# Altium schematic sheet [07] - USB-C Connector.SchDoc("[07] - USB-C Connector")
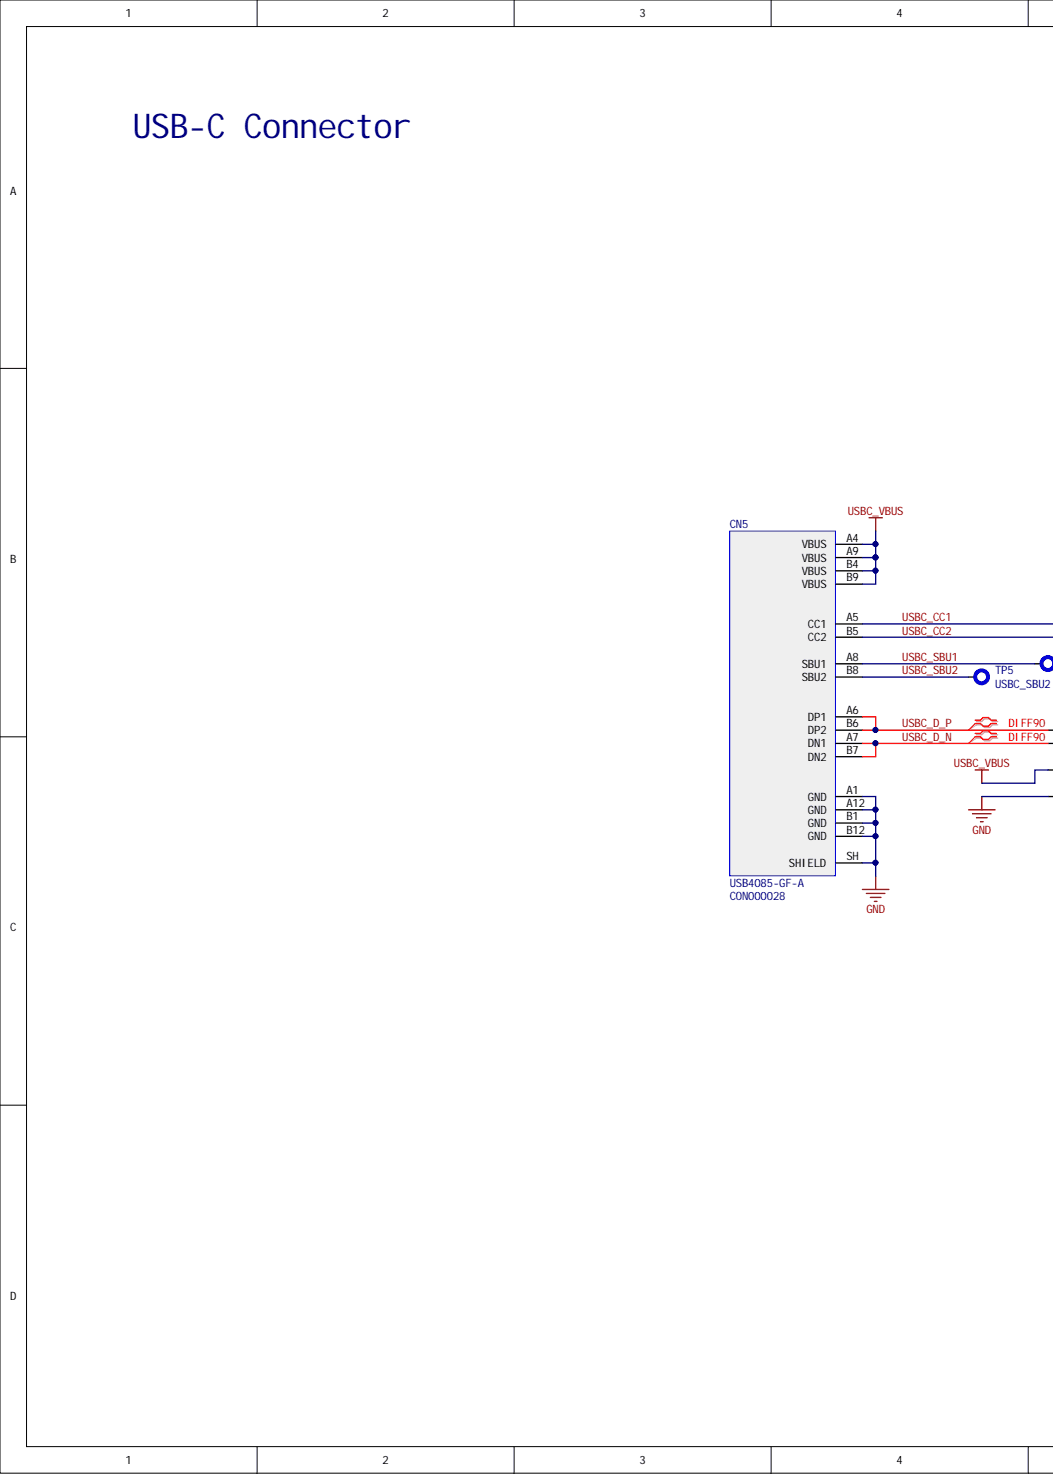
[diagram p0: [07] - USB-C Connector.SchDoc, left]
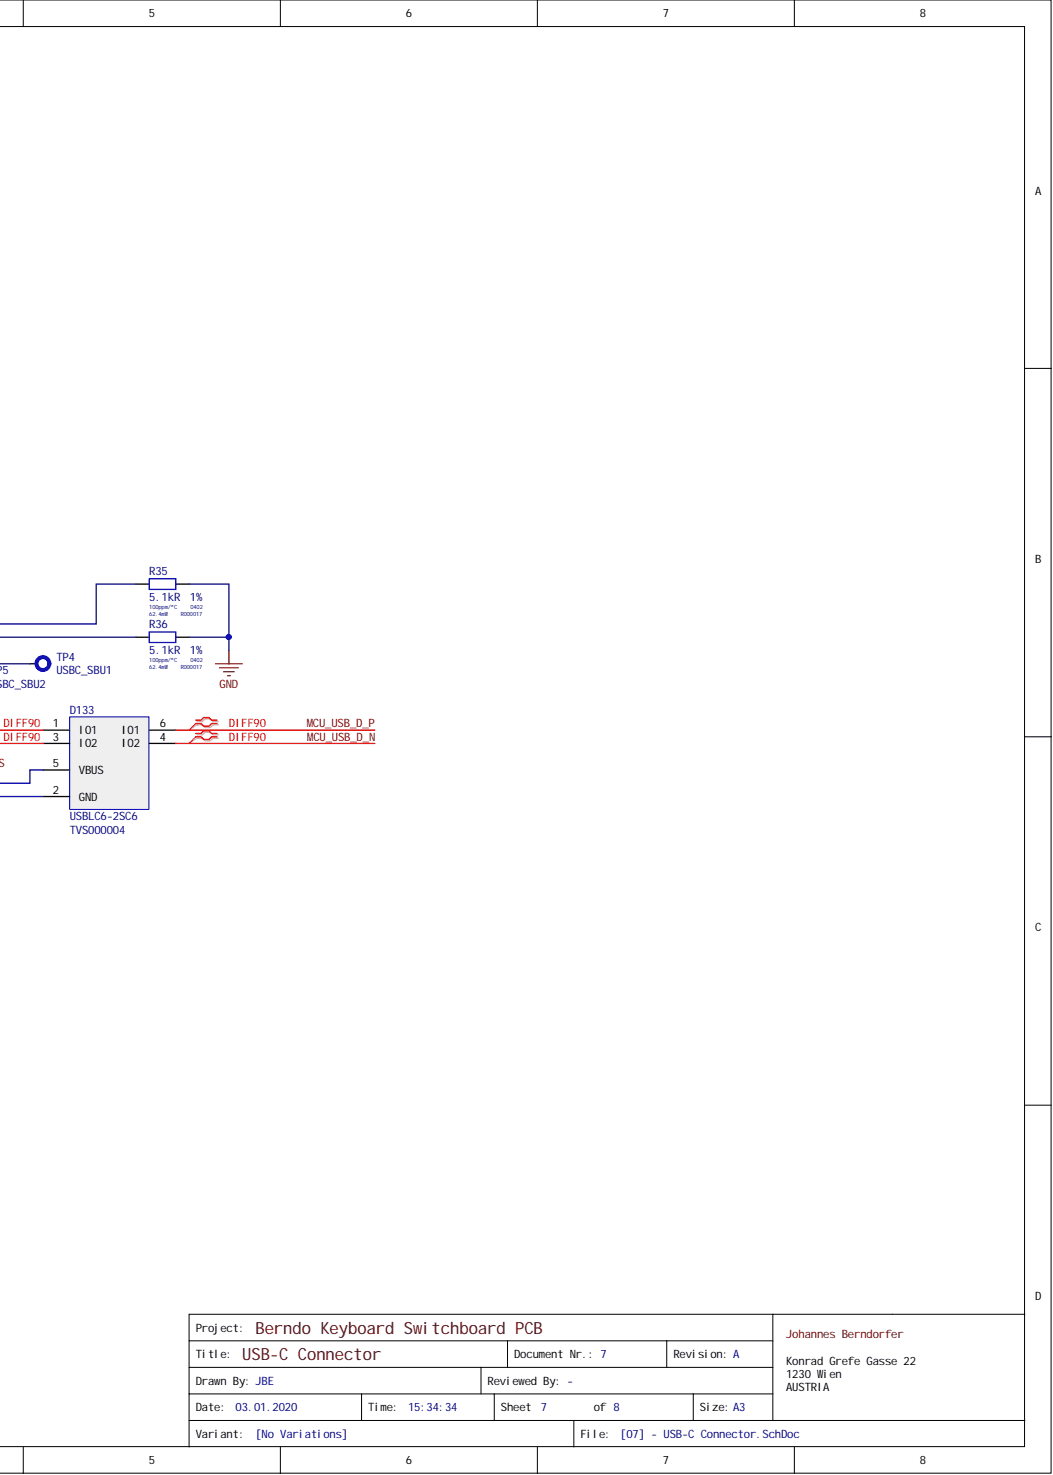
[diagram p1: [07] - USB-C Connector.SchDoc, right]

## Parts
CN5  USB4085-GF-A  [CON-THT-USB-C-16POS-USB4085-GCT]
D133  USBLC6-2SC6  Internal Part Number: TVS000004  [SOT-23-6L-H1.45MM-ST]
R35  Tolerance: 1%  Resistance: 5.1kR  Case Code (Imperial): 0402  [RES-SMD-0402-0.4MM-IPC-MED]  (hidden comment: RC0402FR-075K1L)
R36  Tolerance: 1%  Temperature Coefficient: 100ppm/°C  Resistance: 5.1kR  Max Power Dissipation: 62.4mW  Internal Part Number: R000017  Case Code (Imperial): 0402  [RES-SMD-0402-0.4MM-IPC-MED]  (hidden comment: RC0402FR-075K1L)
TP4  USBC_SBU1  [TP-SMD-CIRCULAR-1MM]
TP5  USBC_SBU2  [TP-SMD-CIRCULAR-1MM]

## Nets
GND: CN5.A1(GND) CN5.A12(GND) CN5.B1(GND) CN5.B12(GND) CN5.SH(SHIELD) D133.2(GND) R35.2 R36.2
USBC_VBUS: CN5.A4(VBUS) CN5.A9(VBUS) CN5.B4(VBUS) CN5.B9(VBUS) D133.5(VBUS)
MCU_USB_D_N: D133.4(IO2)
MCU_USB_D_P: D133.6(IO1)
USBC_CC1: CN5.A5(CC1) R35.1
USBC_CC2: CN5.B5(CC2) R36.1
USBC_D_N: CN5.A7(DN1) CN5.B7(DN2) D133.3(IO2)
USBC_D_P: CN5.A6(DP1) CN5.B6(DP2) D133.1(IO1)
USBC_SBU1: CN5.A8(SBU1) TP4.1
USBC_SBU2: CN5.B8(SBU2) TP5.1

## Library data of the parts
CN5 = USB4085-GF-A: CON USB TYPE C THROUGH HOLE 16POS; Manufacturer: Global Connector Technology; Internal Part Number: CON000028; USB Standard: USB 2.0; Number of Positions: 16; Gender: Receptacle; Supplier Part Number 1: 2073-USB4085-GF-ACT-ND; Supplier 1: Digi-Key; Stock 1: 0; RoHS: No; Orientation: Right Angle; Manufacturer Part Number: USB4085-GF-A; Library Name: Altium_Lib_Standard.IntLib; Contact Plating: Gold; Contact Material: Copper Alloy; ComponentLink2URL: https://datasheet.ciiva.com/71788/usb4085-71788147.pdf?src-supplier=Digi-Key; ComponentLink2Description: https://datasheet.ciiva.com/71788/usb4085-71788147.pdf?src-supplier=Digi-Key; ComponentLink1URL: https://datasheet.ciiva.com/71788/2674755-71788146.pdf?src-supplier=Element14; ComponentLink1Description: https://datasheet.ciiva.com/71788/2674755-71788146.pdf?src-supplier=Element14; Component Kind: Standard
D133 = USBLC6-2SC6: TVS DIODE 2CH 5.25V 17V USB2.0 SOT23-6L; Manufacturer: STMicroelectronics; Working Voltage: 5V; Test Current: 1mA; Reverse Breakdown Voltage: 6V; Power Line Protection: Yes; Polarity: Unidirectional,Bidirectional; Peak Pulse Current: 5A; Operating Supply Voltage: 1.1V; Number of Elements: 1; Number of Channels: 2; Max Reverse Leakage Current: 150nA; Element Configuration: Dual; Data Rate: 480Mbps; Capacitance: 3.5pF; Width: 1.75mm; Termination: SMD/SMT; Supplier Part Number 1: 497-5235-1-ND; Supplier 1: Digi-Key; Stock 1: 30680; RoHS: Yes; Reverse Standoff Voltage: 5.25V; REACH SVHC: No SVHC; Radiation Hardening: No; Pricing 1: 1 = 0,47000, 10 = 0,39900, 100 = 0,29820, 500 = 0,23430, 1000 = 0,18105 (USD); Packaging: Tape and Reel; Package Quantity: 3000; Number of Pins: 6; Number of Bidirectional Channels: 2; Mount: Surface Mount; Min Operating Temperature: -40°C; Min Breakdown Voltage: 6V; Max Operating Temperature: 125°C; Max Breakdown Voltage: 6V; Manufacturer Part Number: USBLC6-2SC6; Library Name: Altium_Lib_Standard.IntLib; Length: 3.05mm; Leakage Current: 150nA; Lead Free: Lead Free; Height: 1.3mm; ESD Protection: Yes; Direction: Unidirectional,Bidirectional; Depth: 1.75mm; Contact Plating: Tin,Matte; ComponentLink4URL: https://datasheet.ciiva.com/16200/cd00050750-16200026.pdf?src-supplier=Future+Electronics; ComponentLink4Description: https://datasheet.ciiva.com/16200/cd00050750-16200026.pdf?src-supplier=Future+Electronics; ComponentLink3URL: https://datasheet.ciiva.com/26787/usblc6-2sc6-26787908.pdf?src-supplier=IIIC; ComponentLink3Description: https://datasheet.ciiva.com/26787/usblc6-2sc6-26787908.pdf?src-supplier=IIIC; ComponentLink2URL: https://datasheet.ciiva.com/4508/cd00050750-113117-4508433.pdf?src-supplier=Mouser; ComponentLink2Description: https://datasheet.ciiva.com/4508/cd00050750-113117-4508433.pdf?src-supplier=Mouser; ComponentLink1URL: https://datasheet.ciiva.com/1268/1690354-1268384.pdf?src-supplier=Element14; ComponentLink1Description: https://datasheet.ciiva.com/1268/1690354-1268384.pdf?src-supplier=Element14; Component Kind: Standard; Clamping Voltage: 17V; Case/Package: SOT-23; Breakdown Voltage: 6V
R35 = RC0402FR-075K1L: RES 5K1 1% 1/16W SMD 0402 YAEGO; Manufacturer: Yageo; Internal Part Number: R000017; Working Voltage: 50V; Temperature Coefficient: 100ppm/°C; Power Rating: 63mW; Max Power Dissipation: 62.4mW; Width: 0.02inch; Voltage Rating (DC): 50V; Voltage Rating: 50V; Termination: SMD/SMT; Supplier Part Number 1: 311-5.10KLRCT-ND; Supplier 1: Digi-Key; Stock 1: 3098997; RoHS: Yes; REACH SVHC: Unknown; Pricing 1: 1 = 0,10000, 10 = 0,01600, 100 = 0,00640, 1000 = 0,00289, 2500 = 0,00251, 5000 = 0,00207 (USD); Packaging: Tape and Reel; Package Quantity: 1; Number of Terminations: 2; Min Operating Temperature: -55°C; Military Standard: Not; Max Operating Temperature: 155°C; Manufacturer Part Number: RC0402FR-075K1L; Library Name: Altium_Lib_Standard.IntLib; Length: 1mm; Lead Free: Lead Free; Height: 0.016inch; Features: Moisture Resistant; Depth: 0.5mm; Composition: Thick Film; ComponentLink8URL: https://datasheet.ciiva.com/7983/1018594-7983519.pdf?src-supplier=Element14; ComponentLink8Description: https://datasheet.ciiva.com/7983/1018594-7983519.pdf?src-supplier=Element14; ComponentLink7URL: https://datasheet.ciiva.com/12558/pyu-rc0402-51-rohs-l-6-r-8721-12558460.pdf?src-supplier=Mouser; ComponentLink7Description: https://datasheet.ciiva.com/12558/pyu-rc0402-51-rohs-l-6-r-8721-12558460.pdf?src-supplier=Mouser; ComponentLink6URL: https://datasheet.ciiva.com/16744/pyu-rc0402-51-rohs-l-6-r-16744283.pdf?src-supplier=Future+Electronics; ComponentLink6Description: https://datasheet.ciiva.com/16744/pyu-rc0402-51-rohs-l-6-r-16744283.pdf?src-supplier=Future+Electronics; ComponentLink5URL: https://datasheet.ciiva.com/2453/pyu-r-int-thick-7-2453402.pdf?src-supplier=Digi-Key; ComponentLink5Description: https://datasheet.ciiva.com/2453/pyu-r-int-thick-7-2453402.pdf?src-supplier=Digi-Key; ComponentLink4URL: https://datasheet.ciiva.com/2453/pyu-r-int-thick-7-2453401.pdf?src-supplier=Digi-Key; ComponentLink4Description: https://datasheet.ciiva.com/2453/pyu-r-int-thick-7-2453401.pdf?src-supplier=Digi-Key; ComponentLink3URL: https://datasheet.ciiva.com/46394/1018594-46394936.pdf?src-supplier=Element14; ComponentLink3Description: https://datasheet.ciiva.com/46394/1018594-46394936.pdf?src-supplier=Element14; ComponentLink2URL: https://datasheet.ciiva.com/2453/pyu-r-int-thick-7-2453400.pdf?src-supplier=Digi-Key; ComponentLink2Description: https://datasheet.ciiva.com/2453/pyu-r-int-thick-7-2453400.pdf?src-supplier=Digi-Key; ComponentLink1URL: https://datasheet.ciiva.com/15189/getdatasheetpartid-323636-15189493.pdf?src-supplier=Verical; ComponentLink1Description: https://datasheet.ciiva.com/15189/getdatasheetpartid-323636-15189493.pdf?src-supplier=Verical; Component Kind: Standard; Case Code (Metric): 1005; Case/Package: 0402
R36 = RC0402FR-075K1L: RES 5K1 1% 1/16W SMD 0402 YAEGO; Manufacturer: Yageo; Working Voltage: 50V; Power Rating: 63mW; Width: 0.02inch; Voltage Rating (DC): 50V; Voltage Rating: 50V; Termination: SMD/SMT; Supplier Part Number 1: 311-5.10KLRCT-ND; Supplier 1: Digi-Key; Stock 1: 3098997; RoHS: Yes; REACH SVHC: Unknown; Pricing 1: 1 = 0,10000, 10 = 0,01600, 100 = 0,00640, 1000 = 0,00289, 2500 = 0,00251, 5000 = 0,00207 (USD); Packaging: Tape and Reel; Package Quantity: 1; Number of Terminations: 2; Min Operating Temperature: -55°C; Military Standard: Not; Max Operating Temperature: 155°C; Manufacturer Part Number: RC0402FR-075K1L; Library Name: Altium_Lib_Standard.IntLib; Length: 1mm; Lead Free: Lead Free; Height: 0.016inch; Features: Moisture Resistant; Depth: 0.5mm; Composition: Thick Film; ComponentLink8URL: https://datasheet.ciiva.com/7983/1018594-7983519.pdf?src-supplier=Element14; ComponentLink8Description: https://datasheet.ciiva.com/7983/1018594-7983519.pdf?src-supplier=Element14; ComponentLink7URL: https://datasheet.ciiva.com/12558/pyu-rc0402-51-rohs-l-6-r-8721-12558460.pdf?src-supplier=Mouser; ComponentLink7Description: https://datasheet.ciiva.com/12558/pyu-rc0402-51-rohs-l-6-r-8721-12558460.pdf?src-supplier=Mouser; ComponentLink6URL: https://datasheet.ciiva.com/16744/pyu-rc0402-51-rohs-l-6-r-16744283.pdf?src-supplier=Future+Electronics; ComponentLink6Description: https://datasheet.ciiva.com/16744/pyu-rc0402-51-rohs-l-6-r-16744283.pdf?src-supplier=Future+Electronics; ComponentLink5URL: https://datasheet.ciiva.com/2453/pyu-r-int-thick-7-2453402.pdf?src-supplier=Digi-Key; ComponentLink5Description: https://datasheet.ciiva.com/2453/pyu-r-int-thick-7-2453402.pdf?src-supplier=Digi-Key; ComponentLink4URL: https://datasheet.ciiva.com/2453/pyu-r-int-thick-7-2453401.pdf?src-supplier=Digi-Key; ComponentLink4Description: https://datasheet.ciiva.com/2453/pyu-r-int-thick-7-2453401.pdf?src-supplier=Digi-Key; ComponentLink3URL: https://datasheet.ciiva.com/46394/1018594-46394936.pdf?src-supplier=Element14; ComponentLink3Description: https://datasheet.ciiva.com/46394/1018594-46394936.pdf?src-supplier=Element14; ComponentLink2URL: https://datasheet.ciiva.com/2453/pyu-r-int-thick-7-2453400.pdf?src-supplier=Digi-Key; ComponentLink2Description: https://datasheet.ciiva.com/2453/pyu-r-int-thick-7-2453400.pdf?src-supplier=Digi-Key; ComponentLink1URL: https://datasheet.ciiva.com/15189/getdatasheetpartid-323636-15189493.pdf?src-supplier=Verical; ComponentLink1Description: https://datasheet.ciiva.com/15189/getdatasheetpartid-323636-15189493.pdf?src-supplier=Verical; Component Kind: Standard; Case Code (Metric): 1005; Case/Package: 0402
TP4 TP5 = TESTPOINT_CIRCULAR_1MM: Library Name: Altium_Lib_Standard.IntLib; Component Kind: Standard
